# Altium schematic sheet RED_CIRCUITRY_TIMER_2.SchDoc(RED_CIRCUITRY_TIMER_2)
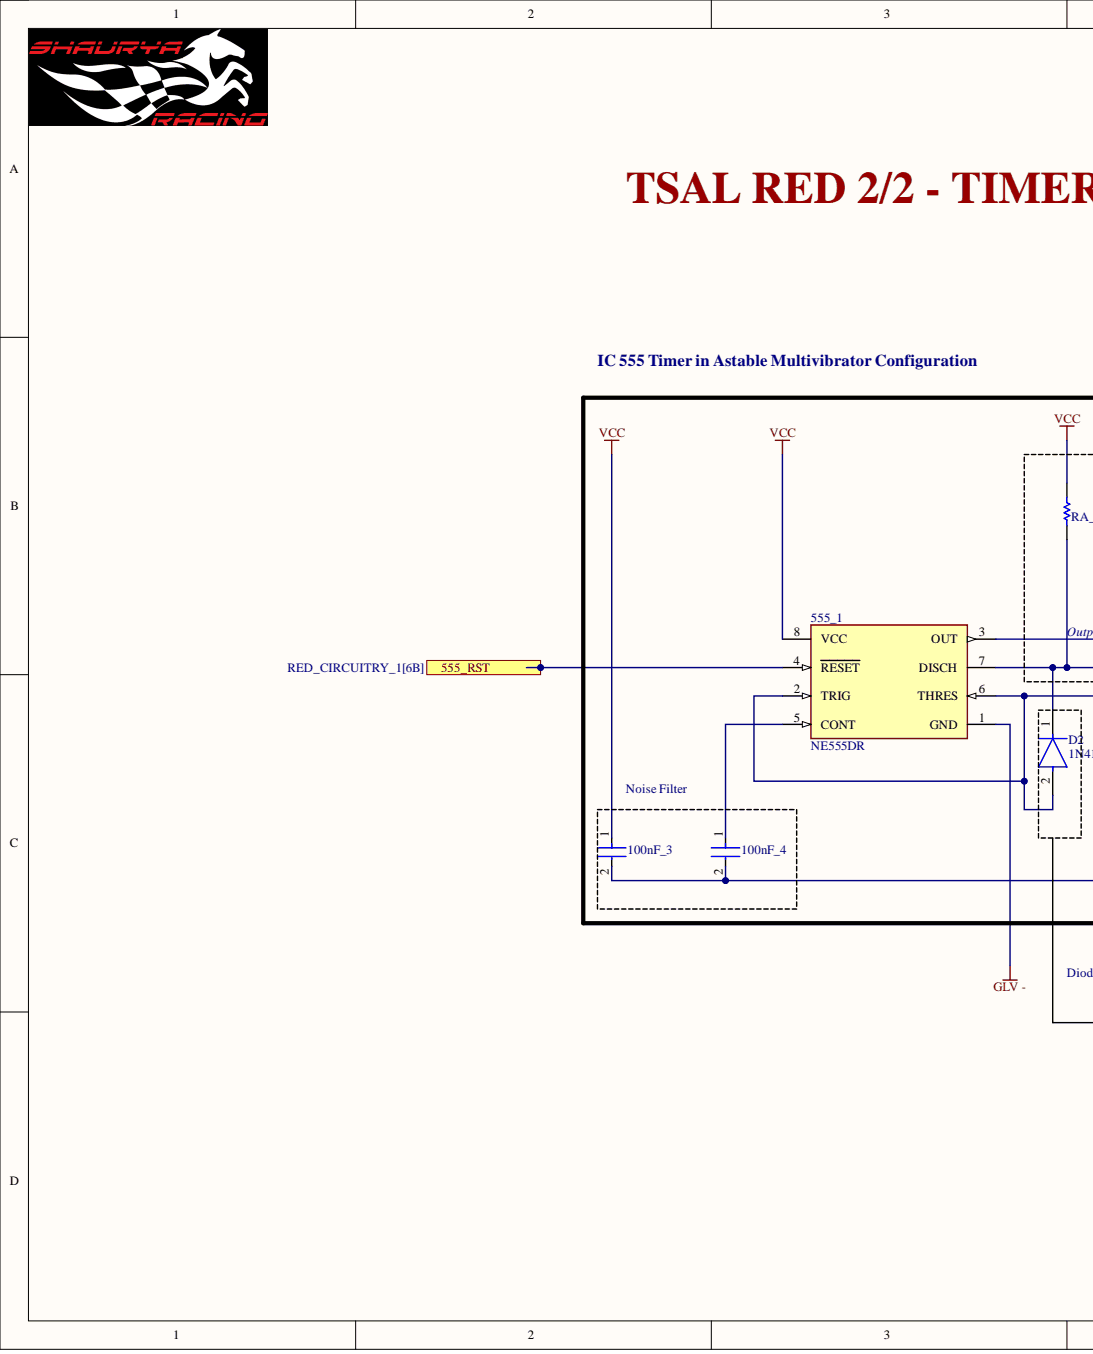
[diagram p0: RED_CIRCUITRY_TIMER_2.SchDoc, left]
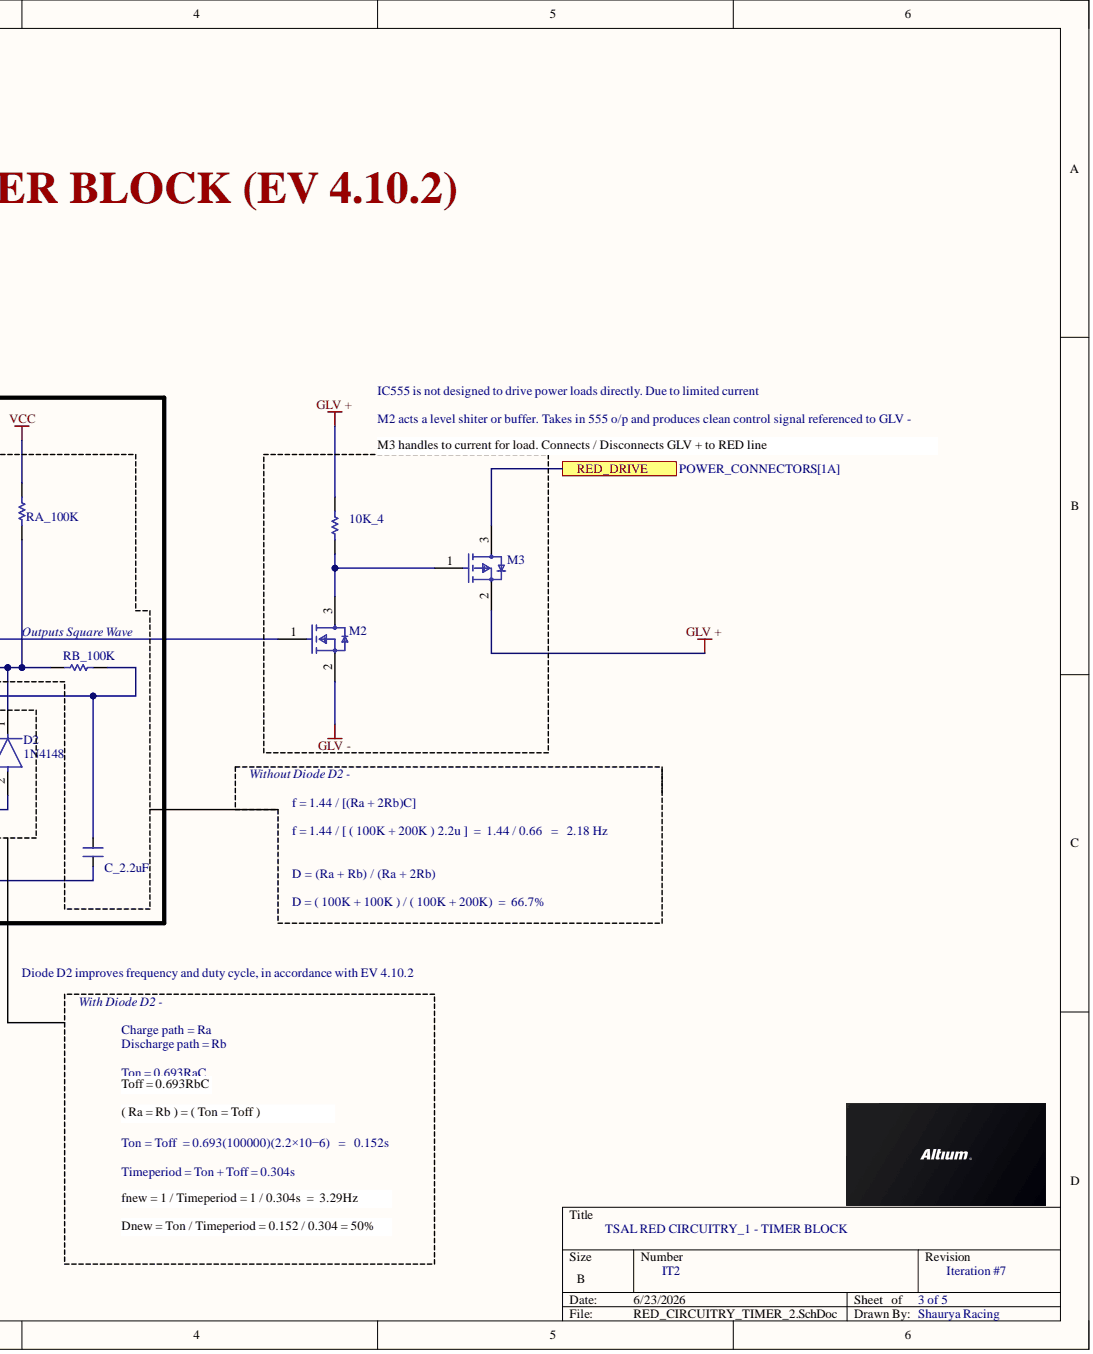
[diagram p1: RED_CIRCUITRY_TIMER_2.SchDoc, right]

## Parts
10K_4  TNPW121010K0BEEN  (value not drawn)
100nF_3  C0805C104K5RACTU  (value not drawn)
100nF_4  C0805C104K5RACTU  (value not drawn)
555_1  NE555DR
C_2.2uF  CAP_2.2uF-10V-10%-0603  (value not drawn)
D2  1N4148
M2  2N7002H6327XTSA2  (value not drawn)
M3  IRLML6402TRPBF  (value not drawn)
RA_100K  TNPW0805100KDEEA  (value not drawn)
RB_100K  TNPW0805100KDEEA  (value not drawn)

## Ports
555_RST, RED_DRIVE

## Nets
GLV +: 10K_4.2 M3.2
GLV -: 555_1.1(GND) M2.2
VCC: 100nF_3.1 555_1.8(VCC) RA_100K.2
555_RST: 555_1.4(RESET) ; port 555_RST
RED_DRIVE: M3.3 ; port RED_DRIVE
Net10K_4_1: 10K_4.1 M2.3 M3.1
Net100nF_3_2: 100nF_3.2 100nF_4.2 C_2.2uF.1
Net100nF_4_1: 100nF_4.1 555_1.5(CONT)
Net555_1_2: 555_1.2(TRIG) 555_1.6(THRES) C_2.2uF.2 D2.2 RB_100K.2
Net555_1_3: 555_1.3(OUT) M2.1
Net555_1_7: 555_1.7(DISCH) D2.1 RA_100K.1 RB_100K.1

## Library data of the parts
10K_4 = CMP-2005-00857-1: Manufacturer: Vishay Dale; Component Kind: Standard; Library Name: Altium Content Vault; Part Number: TNPW121010K0BEEN
100nF_3 100nF_4 = CMP-2007-00279-2: CAP CER 0.1UF 50V X7R 0805; Manufacturer: KEMET; Capacitance: 0.10 μF; Temperature Coefficient: 30ppm/°C; Tolerance: 0.1; Value: 0.10 μF; Automotive: No; Category: Cap; Component Kind: Standard; ComponentLink2Description: Datasheet; ComponentLink2URL: https://content.kemet.com/datasheets/KEM_C1003_C0G_SMD.pdf; Device Class L1: Passive Components; Device Class L2: Capacitors; Device Class L3: Ceramic Capacitors; Digikey Description: CAP CER 0.1UF 50V X7R 0805; Height: 0.88mm; Ipc Land Pattern Name: CAPC200125X78; Lead Free: Yes; Library Name: Altium Content Vault; Manufacturer Part Number: C0805C104K5RACTU; Material: Ceramic; Mouser Description: Cap Ceramic 0.1uF 50V X7R 10% SMD 2.0 x 1.2 mm 125 C Paper T/R; Octopart: https://octopart.com/c0805c104k5ractu-kemet-143926; Package: C0805C-DN; RoHS: TRUE; Temperature: -55°C to +125°C; Temperature Characteristic: X7R; Temperature Range High: +125°C; Temperature Range Low: -55°C; Voltage Rating: 50 V
555_1 = CMP-1685-00007-2: IC OSC SGL TIMER 100KHZ 8-SOIC; High Level Output Current: -200mA; Low Level Output Current: 200mA; Max Supply Voltage: 16V; Min Supply Voltage: 4.5V; Number of Timers/Counters: 1; Operating Supply Current: 10mA; Quiescent Current: 15mA; Case/Package: SOIC; Component Kind: Standard; Contact Plating: Gold; Frequency: 100kHz; Height: 1.58mm; Lead Free: Lead Free; Length: 4.9mm; Library Name: Altium Content Vault; Max Operating Temperature: 70°C; Min Operating Temperature: 0°C; Mount: Surface Mount; Number of Pins: 8; Package Quantity: 2500; Packaging: Tape and Reel; Radiation Hardening: No; REACH SVHC: No SVHC; Weight: 0.002561oz; Width: 3.91mm
C_2.2uF = CMP-001-00120-1: 2.2µF ±10% 16V Ceramic Capacitor X5R 0603 (1608 Metric); Manufacturer: KEMET; Manufacturer Part Number: C0603C225K4PACTU; Case/Package: 0603; Component Kind: Standard; Component Type: Standard; Design Item ID: CAP_2.2uF-10V-10%-0603; Pin Count: 2; Supplier-1: Digikey; Library Name: APAC - VIT, Chennai - Shaurya Racing; Source: EsteemPCB_SOM_Course_schlib.SchLib
D2 = CMP-07163-000046-1: DIODE GEN PURP 100V 200MA DO35; Capacitance: 4pF; Current: 4A; Element Configuration: Single; Forward Current: 300mA; Forward Voltage: 1V; Max Forward Surge Current (Ifsm): 1A; Max Power Dissipation: 500mW; Max Reverse Current: 5uA; Outside Diameter: 1.85mm; Polarity: Standard; Recovery Time: 4ns; Average Rectified Current: 200mA; Case/Package: DO-35; Component Kind: Standard; Contact Plating: Tin; Current Rating: 200mA; Diameter: 1.91mm; Height: 4.56mm; Lead Free: Lead Free; Length: 4.56mm; Library Name: Altium Content Vault; Max Operating Temperature: 175°C; Max Repetitive Reverse Voltage (Vrrm): 100V; Max Reverse Voltage (DC): 100V; Max Surge Current: 4A; Min Operating Temperature: -65°C; Mount: Through Hole; Number of Pins: 2; Output Current: 200mA; Package Quantity: 2000; Packaging: Bulk; Peak Non-Repetitive Surge Current: 4A; Power Dissipation: 500mW; Radiation Hardening: No; REACH SVHC: No SVHC; Reverse Recovery Time: 4ns; Reverse Voltage: 100V; Series: -; Voltage: 100V; Voltage Rating (DC): 100V; Weight: 0.01lb; Width: 1.91mm
M2 = CMP-32901-000180-1: MOSFET N-CH 60V 0.3A SOT23; Continuous Drain Current (ID): 300mA; Gate to Source Voltage (Vgs): 20V; Input Capacitance: 20pF; Max Power Dissipation: 500mW; Rds On Max: 3R; Rise Time: 3.3ns; Case/Package: SOT-23; Component Kind: Standard; Drain to Source Voltage (Vdss): 60V; Halogen Free: Halogen Free; Lead Free: Lead Free; Library Name: Altium Content Vault; Max Dual Supply Voltage: 60V; Max Operating Temperature: 150°C; Min Operating Temperature: -55°C; Mount: Surface Mount; Number of Pins: 3; Packaging: Tape and Reel
M3 = CMP-32901-000130-1: MOSFET P-CH 20V 3.7A SOT-23; Manufacturer: Infineon; Continuous Drain Current: -3.7A; Depletion Mode: False; Drain To Source Breakdown Voltage: -20V; Drain To Source Resistance: 0.05Ω; Gate Charge At Vgs: 8nC @ -5V; Gate To Source Voltage: 12V; Input Capacitace At Vds: 633pF @ -10V; Max Forward Diode Voltage: -1.2V; Max Junction Temp: +150°C; Number Of Channels: 1; Power Dissipation: 1.3W; Pulse Drain Current: -22A; Reverse Recovery Charge: 11nC; Reverse Recovery Time: 29ns; Rthja Max: 75°C/W; Standoff Height: 0.01mm; Threshold Vgs: -0.55V; Threshold Vgs Min: -0.4V; Turn Off Delay Time: 588ns; Turn On Delay Time: 350ns; Automotive: No; Category: Trans; Component Kind: Standard; ComponentLink2Description: Datasheet; ComponentLink2URL: https://www.infineon.com/dgdl/irlml6402pbf.pdf?fileId=5546d462533600a401535668d5c2263c; Device Class L1: Discrete Semiconductors; Device Class L2: Transistors; Device Class L3: MOSFETs; Digikey Description: MOSFET P-CH 20V 3.7A SOT-23; Drain To Source Voltage: -20V; Footprint Url: http://irf.com/package/outline/po_micro3.pdf; Height: 1.12mm; Ipc Land Pattern Name: SOT95P237X100-3; Lead Free: Yes; Library Name: Altium Content Vault; Manufacturer Part Number: IRLML6402TRPBF; Number Of P Channels: 1; Octopart: https://octopart.com/irlml6402trpbf-infineon-65874989; Package: Micro3; RoHS: TRUE; Technology: MOSFET; Temperature: -55°C to +150°C; Temperature Range High: +150°C; Temperature Range Low: -55°C; Threshold Vgs Max: -1.2V
RA_100K RB_100K = CMP-2001-04708-1: Manufacturer: Vishay Dale; Component Kind: Standard; Library Name: Altium Content Vault; Part Number: TNPW0805100KDEEA
